# Altium schematic sheet DASHBOARD.SchDoc
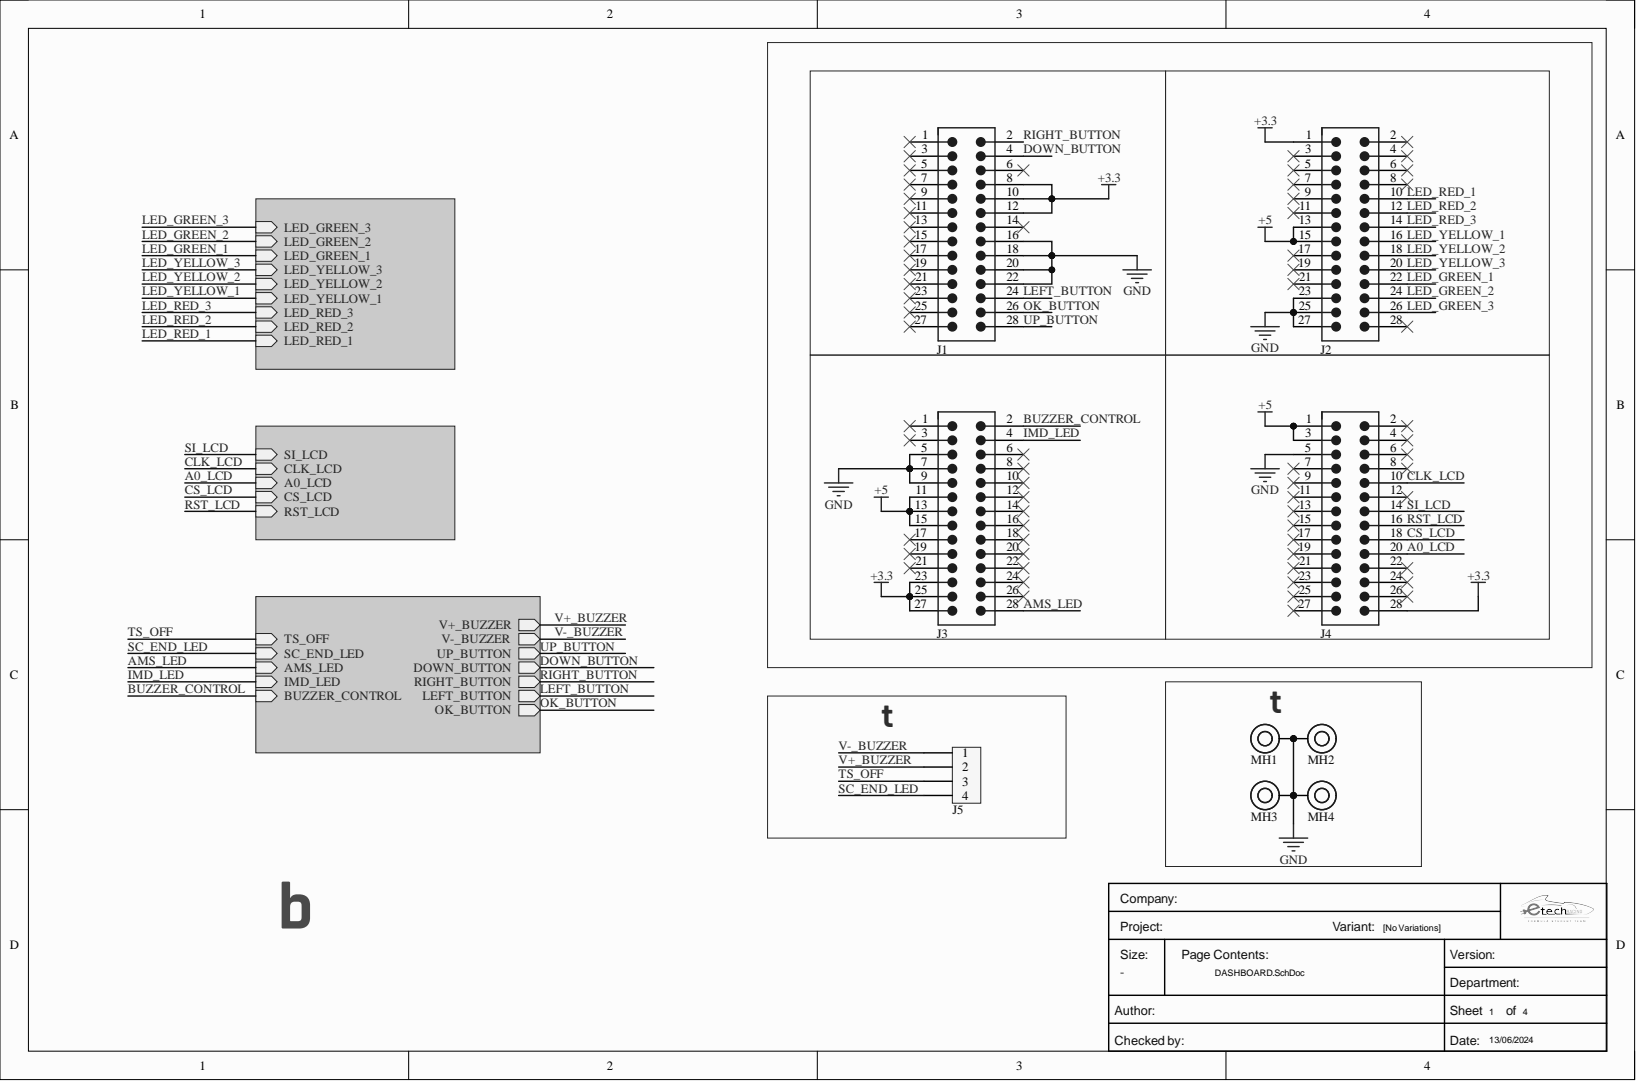
[diagram p0: DASHBOARD.SchDoc]

## Parts
J1  62132821021  [62132821021]  (value not drawn)
J2  62132821021  [62132821021]  (value not drawn)
J3  62132821021  [62132821021]  (value not drawn)
J4  62132821021  [62132821021]  (value not drawn)
J5  436500400  [J_MicroFit_1x4]  (value not drawn)
MH1  Mounting_Hole_M3  [Mounting_Hole_M3]  (value not drawn)
MH2  Mounting_Hole_M3  [Mounting_Hole_M3]  (value not drawn)
MH3  Mounting_Hole_M3  [Mounting_Hole_M3]  (value not drawn)
MH4  Mounting_Hole_M3  [Mounting_Hole_M3]  (value not drawn)

## Nets
+3.3: J1.8 J1.10 J1.12 J2.1 J3.23 J3.25 J3.27 J4.28
+5: J2.13 J2.15 J3.11 J3.13 J3.15 J4.1 J4.3
GND: J1.16 J1.18 J1.20 J1.22 J2.23 J2.25 J2.27 J3.5 J3.7 J3.9 J4.5 MH1.1 MH2.1 MH3.1 MH4.1
A0_LCD: J4.20
AMS_LED: J3.28
BUZZER_CONTROL: J3.2
CLK_LCD: J4.10
CS_LCD: J4.18
DOWN_BUTTON: J1.4
IMD_LED: J3.4
LED_GREEN_1: J2.22
LED_GREEN_2: J2.24
LED_GREEN_3: J2.26
LED_RED_1: J2.10
LED_RED_2: J2.12
LED_RED_3: J2.14
LED_YELLOW_1: J2.16
LED_YELLOW_2: J2.18
LED_YELLOW_3: J2.20
LEFT_BUTTON: J1.24
OK_BUTTON: J1.26
RIGHT_BUTTON: J1.2
RST_LCD: J4.16
SC_END_LED: J5.4
SI_LCD: J4.14
TS_OFF: J5.3
UP_BUTTON: J1.28
V+_BUZZER: J5.2
V-_BUZZER: J5.1
NetJ1_1: J1.1
NetJ1_3: J1.3
NetJ1_5: J1.5
NetJ1_6: J1.6
NetJ1_7: J1.7
NetJ1_9: J1.9
NetJ1_11: J1.11
NetJ1_13: J1.13
NetJ1_14: J1.14
NetJ1_15: J1.15
NetJ1_17: J1.17
NetJ1_19: J1.19
NetJ1_21: J1.21
NetJ1_23: J1.23
NetJ1_25: J1.25
NetJ1_27: J1.27
NetJ2_2: J2.2
NetJ2_3: J2.3
NetJ2_4: J2.4
NetJ2_5: J2.5
NetJ2_6: J2.6
NetJ2_7: J2.7
NetJ2_8: J2.8
NetJ2_9: J2.9
NetJ2_11: J2.11
NetJ2_17: J2.17
NetJ2_19: J2.19
NetJ2_21: J2.21
NetJ2_28: J2.28
NetJ3_1: J3.1
NetJ3_3: J3.3
NetJ3_6: J3.6
NetJ3_8: J3.8
NetJ3_10: J3.10
NetJ3_12: J3.12
NetJ3_14: J3.14
NetJ3_16: J3.16
NetJ3_17: J3.17
NetJ3_18: J3.18
NetJ3_19: J3.19
NetJ3_20: J3.20
NetJ3_21: J3.21
NetJ3_22: J3.22
NetJ3_24: J3.24
NetJ3_26: J3.26
NetJ4_2: J4.2
NetJ4_4: J4.4
NetJ4_6: J4.6
NetJ4_7: J4.7
NetJ4_8: J4.8
NetJ4_9: J4.9
NetJ4_11: J4.11
NetJ4_12: J4.12
NetJ4_13: J4.13
NetJ4_15: J4.15
NetJ4_17: J4.17
NetJ4_19: J4.19
NetJ4_21: J4.21
NetJ4_22: J4.22
NetJ4_23: J4.23
NetJ4_24: J4.24
NetJ4_25: J4.25
NetJ4_26: J4.26
NetJ4_27: J4.27

## Library data of the parts
J1-J4 = 62132821021: WR-PHD Pin Header, SMT, Vertical, pitch 1.27mm, 2 Row, 28P; Manufacturer: Wurth Elektronik; Contact Resistance: 20mΩ; Rated Current: 1A; Withstanding Voltage: 500V; Working Voltage: 100V; Category: Board-to-Board Connectors; Component Kind: Standard; ComponentLink1Description: Website Link; ComponentLink1URL: https://www.we-online.com/redexpert/article/62132821021?ad; ComponentLink2Description: Datasheet Link; ComponentLink2URL: https://www.we-online.com/redexpert/spec/62132821021?ad; Gender: Pin Header; Library Name: Electromechanical.SchLib; Manufacturer Part Number: 62132821021; Match Code: WR-PHD; Mount: Surface Mount; Number of Pins: 28; Number of Rows: 2; Operating Temperature Max: 105°C; Operating Temperature Min: -40°C; Orientation: Vertical; Packaging: Tape and Reel; Pitch: 1.27mm
J5 = J_MicroFit_1x4: Connector Wire to Board Header 4 Position 3mm Solder Right Angle Thru-Hole Tray; Manufacturer 1: Molex; Manufacturer Part Number 1: 43650-0400; Connector Type: Plug; Contact Resistance: 10mR; Current Rating: 5A; Gender: Male; Glow Wire Compliant: Compliant; Max Voltage Rating (AC): 600V; Number of Circuits: 4; Voltage Rating (DC): 250V; Category: Headers and Wire Housings; Color: Black; Component Kind: Standard; ComponentLink1Description: https://datasheet.ciiva.com/19462/884184-19462234.pdf?src-supplier=Jameco; ComponentLink1URL: https://datasheet.ciiva.com/19462/884184-19462234.pdf?src-supplier=Jameco; ComponentLink2Description: https://datasheet.ciiva.com/5151/0436500400-pcb-headers-148663-5151881.pdf?src-supplier=Mouser; ComponentLink2URL: https://datasheet.ciiva.com/5151/0436500400-pcb-headers-148663-5151881.pdf?src-supplier=Mouser; ComponentLink3Description: https://datasheet.ciiva.com/16085/0436500400-pcb-headers-16085752.pdf?src-supplier=Onlinecomponents.com; ComponentLink3URL: https://datasheet.ciiva.com/16085/0436500400-pcb-headers-16085752.pdf?src-supplier=Onlinecomponents.com; ComponentLink4Description: https://datasheet.ciiva.com/11748/0900766b80d6135b-11748178.pdf?src-supplier=RSComponents; ComponentLink4URL: https://datasheet.ciiva.com/11748/0900766b80d6135b-11748178.pdf?src-supplier=RSComponents; ComponentLink5Description: https://datasheet.ciiva.com/30221/142510436500400-pcb-headers-30221164.pdf?src-supplier=Onlinecomponents.com; ComponentLink5URL: https://datasheet.ciiva.com/30221/142510436500400-pcb-headers-30221164.pdf?src-supplier=Onlinecomponents.com; ComponentLink6Description: https://datasheet.ciiva.com/17080/70266896-17080471.pdf?src-supplier=Allied+Electronics; ComponentLink6URL: https://datasheet.ciiva.com/17080/70266896-17080471.pdf?src-supplier=Allied+Electronics; ComponentLink7Description: https://datasheet.ciiva.com/7094/1946229-7094763.pdf?src-supplier=Newark; ComponentLink7URL: https://datasheet.ciiva.com/7094/1946229-7094763.pdf?src-supplier=Newark; ComponentLink8Description: https://datasheet.ciiva.com/9449/0436500400-pcb-headers-9449446.pdf?src-supplier=Avnet; ComponentLink8URL: https://datasheet.ciiva.com/9449/0436500400-pcb-headers-9449446.pdf?src-supplier=Avnet; Contact Material: Brass,Copper Alloy; Contact Plating: Tin; Depth: 3.6mm; Flammability Rating: UL94 V-0; Halogen Free: Low Halogen; Height: 4.5mm; Housing Color: Black; Housing Material: Thermoplastic; Lead Free: Contains Lead; Length: 14.86mm; Library Name: Electromechanical.SchLib; Max Operating Temperature: 105°C; Min Operating Temperature: -40°C; Mount: Through Hole,Cable; Number of Contacts: 4; Number of Positions: 4; Number of Rows: 1; Orientation: Right Angle; Package Quantity: 2380; Packaging: Tray; Pitch: 3mm; Pricing 1: 1=1,24000, 10=1,22000, 50=1,19000, 100=1,17000, 500=1,14000, 1000=1,12000 (EUR); Radiation Hardening: No; RoHS: Yes; RoHS Compliant: Yes; Series: 43650; Stock 1: 4623; Supplier 1: Farnell; Supplier Part Number 1: 1012232; Termination: Solder; Voltage Rating: 600V
MH1-MH4 = Mounting_Hole_M3: Component Kind: Standard; Library Name: Electromechanical.SchLib
